# Altium schematic sheet Power.SchDoc
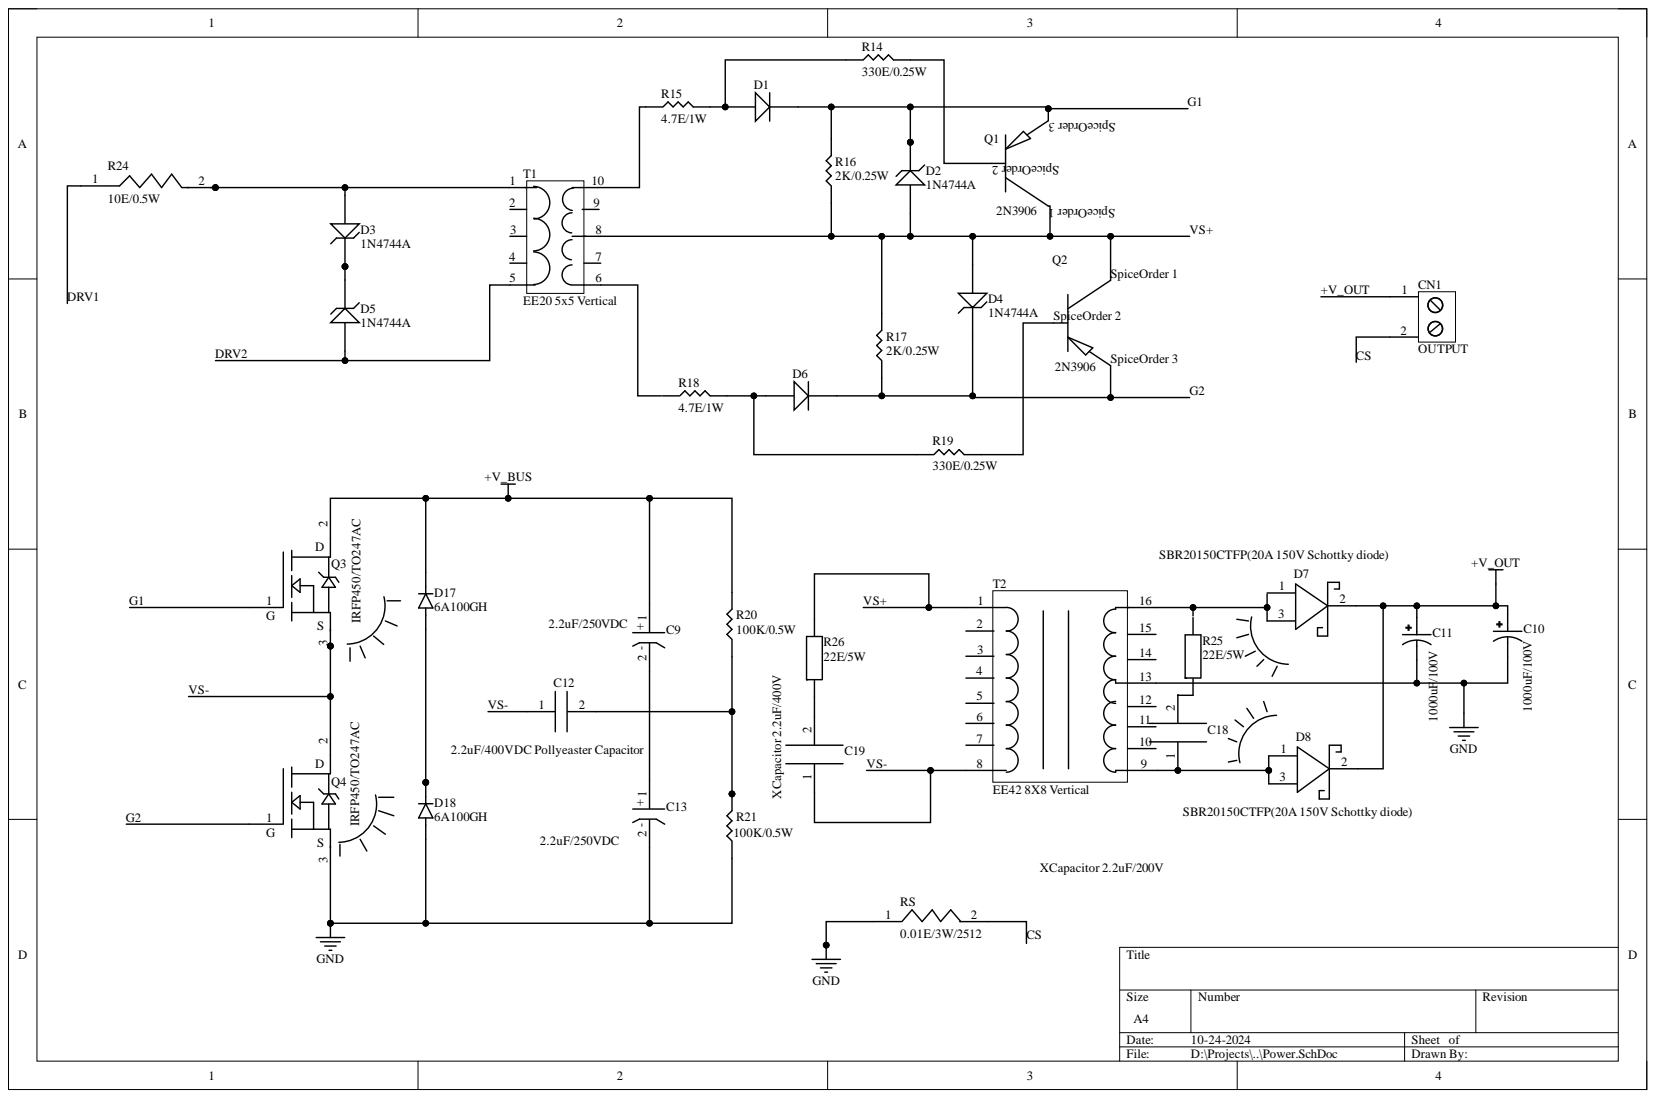
[diagram p0: Power.SchDoc]

## Parts
C9  2.2uF/250VDC  [8mm Dia Eletrolytic Cap]
C10  1000uF/100V  [CAPPRD750W80D1800H3550]
C11  1000uF/100V  [CAPPRD750W80D1800H3550]
C12  2.2uF/400VDC Pollyeaster Capacitor  [29 mm pitch polly cap]
C13  2.2uF/250VDC  [8mm Dia Eletrolytic Cap]
C18  XCapacitor 2.2uF/200V  [X Cpacitor 1-100nF/400V]
C19  XCapacitor 2.2uF/400V  [X Cpacitor 1-100nF/400V]
CN1  OUTPUT  [2 PINTerminal Block]
D1  Diode  (value not drawn)
D2  BV: 1N4744A  (hidden comment: Zener Diode)
D3  BV: 1N4744A  (hidden comment: Zener Diode)
D4  BV: 1N4744A  (hidden comment: Zener Diode)
D5  BV: 1N4744A  (hidden comment: Zener Diode)
D6  Diode  (value not drawn)
D7  SBR20150CTFP(20A 150V Schottky diode)  [TO220AB]
D8  SBR20150CTFP(20A 150V Schottky diode)  [TO220AB]
D17  6A100GH  [DIOAD1950W125L885D700]
D18  6A100GH  [DIOAD1950W125L885D700]
Q1  2N3906  [TO92]
Q2  2N3906  [TO92]
Q3  IRFP450/TO247AC  [TO-247AC]
Q4  IRFP450/TO247AC  [TO-247AC]
R14  330E/0.25W  [0.25W TH Resistor]
R15  4.7E/1W  [0.25W TH Resistor]
R16  2K/0.25W  [0.25W TH Resistor]
R17  2K/0.25W  [0.25W TH Resistor]
R18  4.7E/1W  [0.25W TH Resistor]
R19  330E/0.25W  [0.25W TH Resistor]
R20  100K/0.5W  [0.25W TH Resistor]
R21  100K/0.5W  [0.25W TH Resistor]
R24  10E/0.5W  [1W Resistor]
R25  22E/5W  [5W wire wound resistor]
R26  22E/5W  [5W wire wound resistor]
RS  0.01E/3W/2512  [2512]
T1  EE20 5x5 Vertical  [E20 5x5 Vertical]
T2  EE42 8X8 Vertical  [EE42 8x8 Vertical]

## Nets
+V_BUS: C9.1 D17.C Q3.2 R20.2
GND: C10.N C11.N C13.2 D18.A Q4.3 R21.1 RS.1 T2.13
+V_OUT: C10.P C11.P CN1.1 D7.2 D8.2
~{}: D1.2 D2.2 Q1.3 Q3.1 R16.2
CS: CN1.2 RS.2
DRV1: R24.1
DRV2: D5.1 T1.5
G2: D4.2 D6.2 Q2.3 Q4.1 R17.1
VS+: D2.1 D4.1 Q1.1 Q2.1 R16.1 R17.2 R26.2 T1.8 T2.1
VS-: C12.1 C19.1 Q3.3 Q4.2 T2.8
NetC9_2: C9.2 C13.1
NetC12_2: C12.2 R20.1 R21.2
NetC18_1: C18.1 D8.1 D8.3 T2.9
NetC18_2: C18.2 R25.1
NetC19_2: C19.2 R26.1
NetD1_1: D1.1 R14.1 R15.2
NetD3_1: D3.1 R24.2 T1.1
NetD3_2: D3.2 D5.2
NetD6_1: D6.1 R18.2 R19.1
NetD7_3: D7.3 T2.16
NetD17_A: D17.A D18.C
NetQ1_2: Q1.2 R14.2
NetQ2_2: Q2.2 R19.2
NetR15_1: R15.1 T1.10

Not connected: D7 1; R18 1; R25 2; T1 2 3 4 6 7 9; T2 2 3 4 5 6 7 10 11 12 14 15

## Library data of the parts
C9 C13 = 8 Dia Cap: Component Kind: Standard; Library Name: Custom1.IntLib
C10 C11 = ECA2AM102: 1000ÂµF 100V Aluminum Electrolytic Capacitors Radial, Can 2000 Hrs @ 85Â°C; MF: Panasonic; Availability: In Stock; Check_prices: https://www.snapeda.com/parts/ECA2AM102/Panasonic/view-part/?ref=eda; Component Kind: Standard; Library Name: 1000uF-100VEL.IntLib; MP: ECA2AM102; Package: RADIAL-2 Panasonic; Price: None; SnapEDA_Link: https://www.snapeda.com/parts/ECA2AM102/Panasonic/view-part/?ref=snap
C12 = 29 mmpitch pollyeaster cap: Component Kind: Standard; Library Name: Custom1.IntLib
C18 C19 = XCapacitor 1-100nF/400v: Component Kind: Standard; Library Name: Custom1.IntLib
CN1 = 2 PIN Terminal connector: Component Kind: Standard; Library Name: Custom1.IntLib
D1 D6 = Diode: AF: 1; BV: 0; BV_MAX: 1e99; EG: 1.11; FC: 0.5; IBV: 0.001; IS: 1e-14; MJSW: 0.33; NTUN: 30; SimulationGenericComponent: True; TNOM: 27; XTI: 3; CJO: 0; CJP: 0; Component Kind: Standard; CTA: 0; CTP: 0; Description: Diode; FCS: 0.5; FV_MAX: 1e99; IKF: 0; IKR: 0; ISR: 1e-14; JSW: 0; JTUN: 0; JTUNSW: 0; KEG: 1; KF: 0; LEVEL: 1; Library Name: Simulation Generic Components.IntLib; N: 1; NBV: 1; NR: 1; NS: 1; PHP: 1; RS: 0; Simulation: diode; TCV: 0; TLEV: 0; TLEVC: 0; TM1: 0; TM2: 0; TPB: 0; TPHP: 0; TRS: 0; TRS2: 0; TT: 0; TTT1: 0; TTT2: 0; VJ: 1; XTITUN: 3
D2-D5 = Zener Diode: AF: 1; BV_MAX: 1e99; CJO: 0; EG: 1.11; FC: 0.5; IBV: 0.001; IS: 1e-14; MJSW: 0.33; NTUN: 30; SimulationGenericComponent: True; TNOM: 27; XTI: 3; CJP: 0; Component Kind: Standard; CTA: 0; CTP: 0; Description: Zener Diode; FCS: 0.5; FV_MAX: 1e99; IKF: 0; IKR: 0; ISR: 1e-14; JSW: 0; JTUN: 0; JTUNSW: 0; KEG: 1; KF: 0; LEVEL: 1; Library Name: Simulation Generic Components.IntLib; N: 1; NBV: 1; NR: 1; NS: 1; PHP: 1; RS: 0; Simulation: diode; TCV: 0; TLEV: 0; TLEVC: 0; TM1: 0; TM2: 0; TPB: 0; TPHP: 0; TRS: 0; TRS2: 0; TT: 0; TTT1: 0; TTT2: 0; VJ: 1; XTITUN: 3
Q1 Q2 = 2N3906: Bipolar Transistor PNP Amplifier/Switch 40V 200mA 3-Pin TO-92 Through Hole Box; MF: STMicroelectronics; Availability: In Stock; Check_prices: https://www.snapeda.com/parts/2N3906/STMicroelectronics/view-part/?ref=eda; Component Kind: Standard; Library Name: 2N3906.IntLib; MP: 2N3906; Package: E-PKG AXIAL-LEADED-2 ON Semiconductor; Price: None; Purchase-URL: https://pricing.snapeda.com/search/part/2N3906/?ref=eda; SnapEDA_Link: https://www.snapeda.com/parts/2N3906/STMicroelectronics/view-part/?ref=snap
Q3 Q4 = TO247AC MOSFET: Component Kind: Standard; Library Name: Custom1.IntLib
R14-R21 = 0.25W TH resistor: Component Kind: Standard; Library Name: Custom1.IntLib
R24 = 1W carbon Resistor: Component Kind: Standard; Library Name: Custom1.IntLib
R25 R26 = 5W WR resistor: Component Kind: Standard; Library Name: Custom1.IntLib
RS = 2512 Res: Component Kind: Standard; Library Name: Custom1.IntLib
D7 D8 = SBR20150CTFP(20A 150V Schottky diode): Component Kind: Standard; Library Name: Custom1.IntLib
D17 D18 = 6A100GH: Availability: Unavailable; Component Kind: Standard; Library Name: 6A100GH.IntLib; MP: 6A100GH; Package: None; Price: None
T1 = EE20 5x5 Vertical: Component Kind: Standard; Library Name: Custom1.IntLib
T2 = EE42 8X8 Vertical: Component Kind: Standard; Library Name: Custom1.IntLib
